# Altium schematic sheet 电源.SchDoc
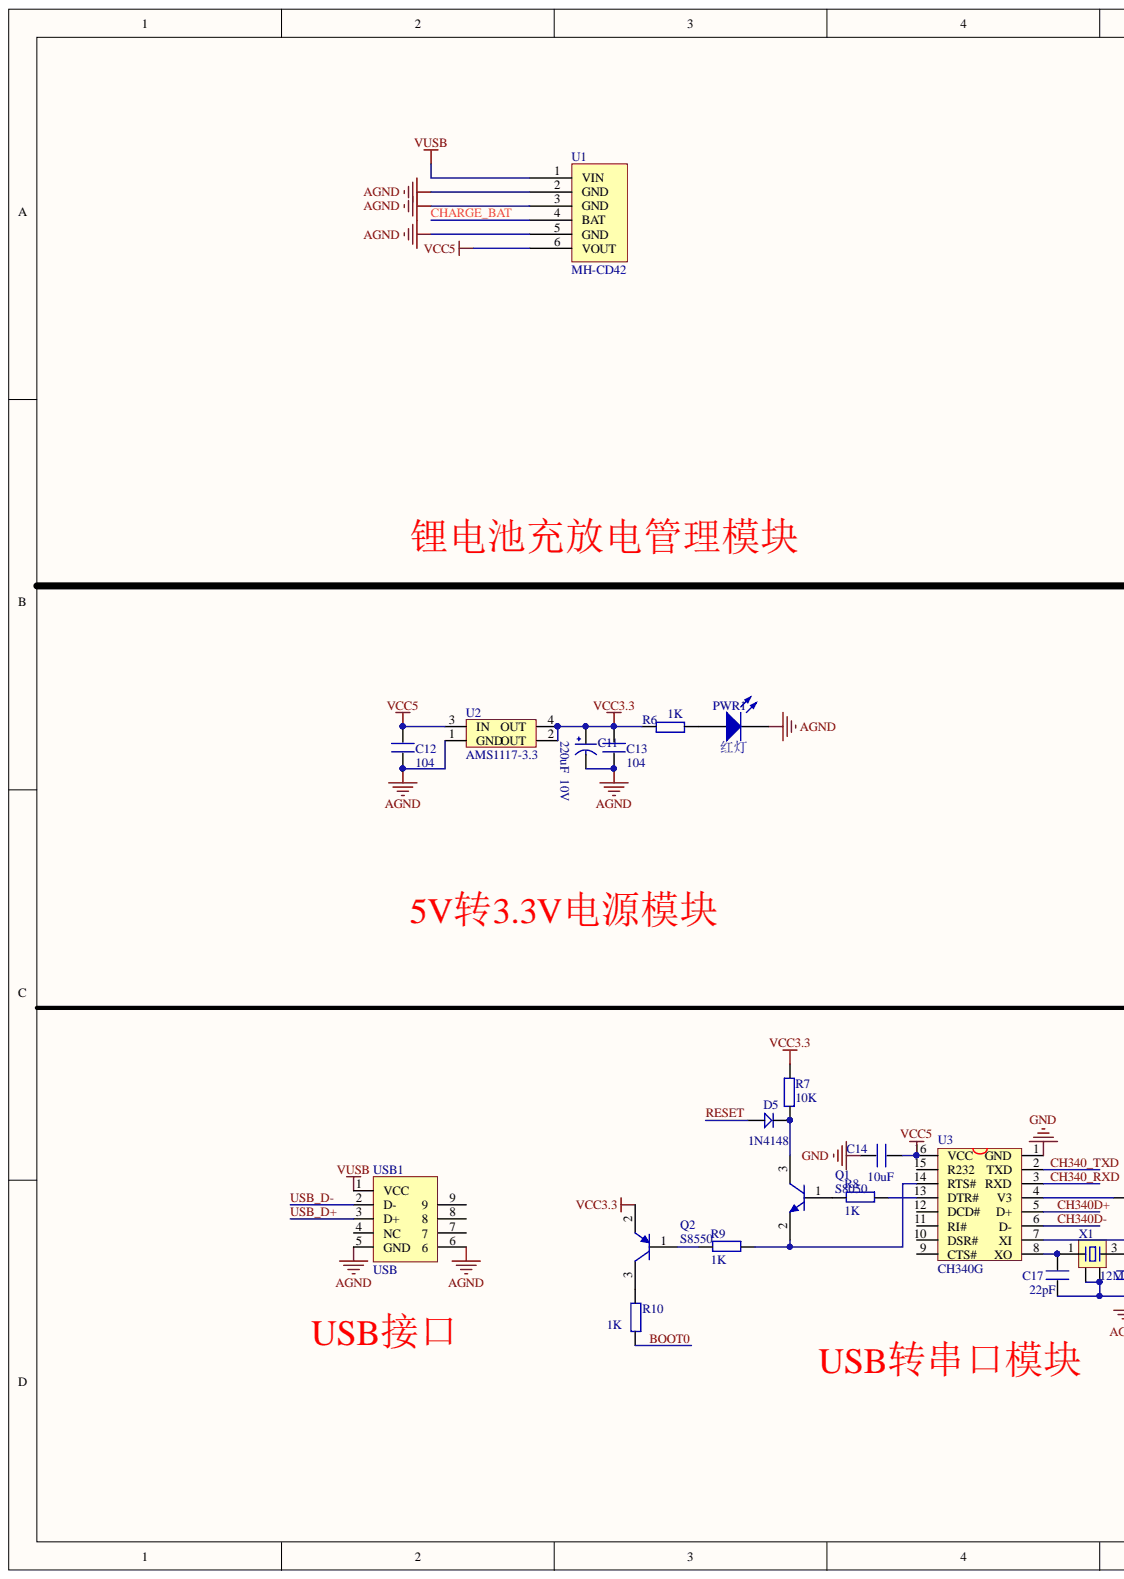
[diagram p0: 电源.SchDoc, left]
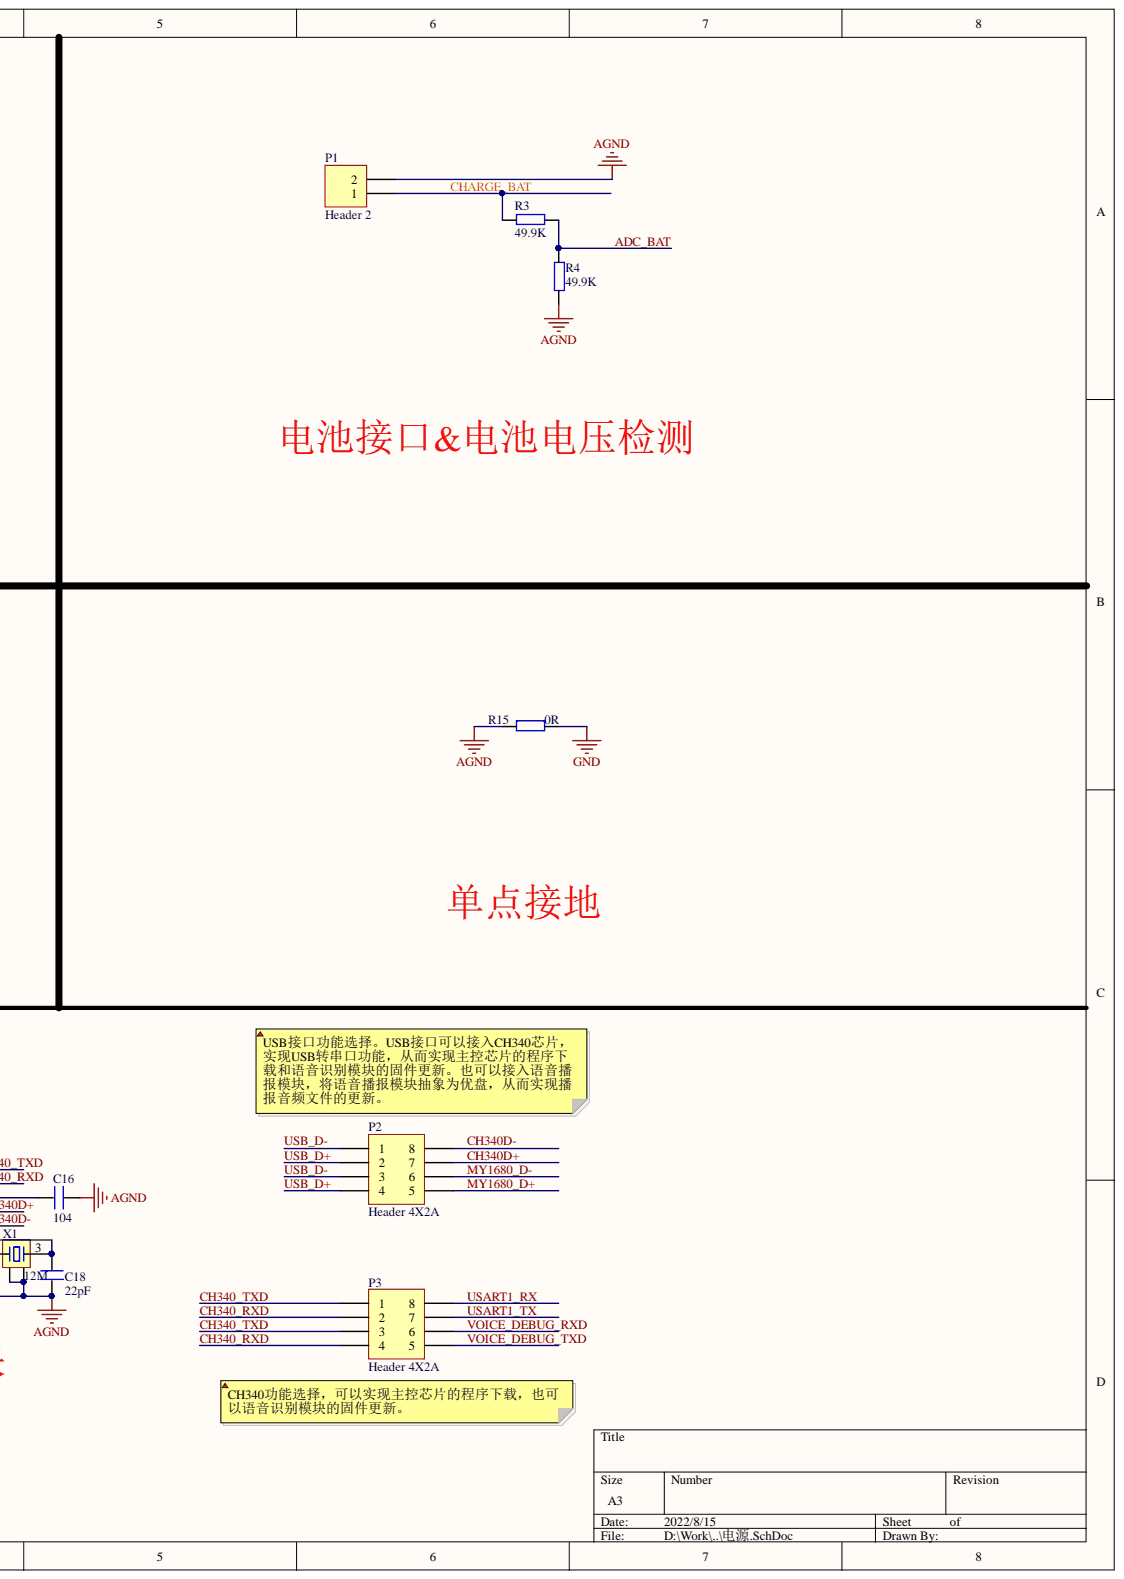
[diagram p1: 电源.SchDoc, right]

## Parts
C11  220uF  10V  [CC6.56.5]
C12  104  [0603C]
C13  104  [0603C]
C14  10uF  [0603C]
C16  104  [0603C]
C17  22pF  [0603C]
C18  22pF  [0603C]
D5  1N4148  [DIODE_SOP]
P1  Header 2  [XH2.54]
P2  Header 4X2A  [拨码开关]
P3  Header 4X2A  [拨码开关]
PWR1  红灯  [LC-0805_LED]
Q1  S8050  [SOT-23]
Q2  S8550  [SOT-23]
R3  49.9K  [0603R]
R4  49.9K  [0603R]
R6  1K  [0603R]
R7  10K  [0603R]
R8  1K  [0603R]
R9  1K  [0603R]
R10  1K  [0603R]
R15  value 0R  [0603R]  (hidden comment: RES)
U1  MH-CD42  [MH-CD42]
U2  AMS1117-3.3  [AMS1117]
U3  CH340G  [SOP-16]
USB1  USB  [MINIUSB]
X1  12M  [3225]

## Nets
AGND: C11.2 C12.1 C13.1 C16.1 C17.2 C18.2 P1.2 PWR1.2 R4.1 R15.2 U1.2(GND) U1.3(GND) U1.5(GND) U2.1(GND) USB1.5(GND) USB1.6 X1.2 X1.4
GND: C14.2 R15.1 U3.1(GND)
VCC3.3: C11.1 C13.2 Q2.2 R6.1 R7.1 U2.2(OUT) U2.4(OUT)
VCC5: C12.2 C14.1 U1.6(VOUT) U2.3(IN) U3.16(VCC)
VUSB: U1.1(VIN) USB1.1(VCC)
ADC_BAT: R3.1 R4.2
BOOT0: R10.1
CH340_RXD: P3.2 P3.4 U3.3(RXD)
CH340_TXD: P3.1 P3.3 U3.2(TXD)
CH340D+: P2.7 U3.5(D+)
CH340D-: P2.8 U3.6(D-)
CHARGE_BAT: P1.1 R3.2 U1.4(BAT)
MY1680_D+: P2.5
MY1680_D-: P2.6
RESET: D5.1
USART1_RX: P3.8
USART1_TX: P3.7
USB_D+: P2.2 P2.4 USB1.3(D+)
USB_D-: P2.1 P2.3 USB1.2(D-)
VOICE_DEBUG_RXD: P3.6
VOICE_DEBUG_TXD: P3.5
NetC16_2: C16.2 U3.4(V3)
NetC17_1: C17.1 U3.8(XO) X1.1
NetC18_1: C18.1 U3.7(XI) X1.3
NetD5_2: D5.2 Q1.3 R7.2
NetPWR1_1: PWR1.1 R6.2
NetQ1_1: Q1.1 R8.2
NetQ1_2: Q1.2 R9.1 U3.14(RTS#)
NetQ2_1: Q2.1 R9.2
NetQ2_3: Q2.3 R10.2
NetR8_1: R8.1 U3.13(DTR#)

Not connected: U3 9(CTS#) 10(DSR#) 11(RI#) 12(DCD#) 15(R232); USB1 4(NC) 7 8 9

## Library data of the parts
C11 = CAP: Component Kind: Standard; Library Name: WarShip V3 STM32103封装库.IntLib
C12-C14 C16-C18 = C: Component Kind: Standard; Library Name: WarShip V3 STM32103封装库.IntLib
D5 = D: Component Kind: Standard; Library Name: WarShip V3 STM32103封装库.IntLib
P1 = Header 2: Header, 2-Pin; Component Kind: Standard; LatestRevisionDate: 17-Jul-2002; LatestRevisionNote: Re-released for DXP Platform.; Library Name: Miscellaneous Connectors.IntLib; Publisher: Altium Limited
P2 P3 = Header 4X2A: Header, 4-Pin, Dual row; Component Kind: Standard; LatestRevisionDate: 17-Jul-2002; LatestRevisionNote: Re-released for DXP Platform.; Library Name: Miscellaneous Connectors.IntLib; Signal Integrity: Connector; Publisher: Altium Limited
PWR1 = LED2: Typical RED, GREEN, YELLOW, AMBER GaAs LED; Component Kind: Standard; LatestRevisionDate: 17-Jul-2002; LatestRevisionNote: Re-released for DXP Platform.; Library Name: WarShip V3 STM32103封装库.IntLib; PackageDocument: Feb-2002; PackageReference: 3.2X1.6X1.1; Published: 8-Jun-2000; Simulation: LED2; Publisher: Altium Limited
Q1 = NPN: Component Kind: Standard; Description: NPN; Library Name: Basic.SchLib
Q2 = 2N3906: PNP General Purpose Amplifier; Component Kind: Standard; ComponentLink1Description: Manufacturer Link; ComponentLink1URL: http://www.fairchildsemi.com/; ComponentLink2Description: Datasheet; ComponentLink2URL: http://www.fairchildsemi.com/ds/2N%2F2N3906.pdf; DatasheetVersion: 1997; LatestRevisionDate: 15-Jan-2003; LatestRevisionNote: PCB Footprint 'BCY-W3/D4.7' replaced; Library Name: Miscellaneous Devices.IntLib; PackageDescription: TO, Flat Index; 3 In-Line, Axial Leads; Body Dia. 4.5mm; Leads 0.51 x 0.48 mm (max); PackageReference: TO-92A; PackageVersion: Sep-1998; Published: 8-Jun-2000; Signal Integrity: 2N3906; Simulation: 2N3906; Publisher: Altium Limited
R3 R4 R6-R10 = R: Component Kind: Standard; Library Name: WarShip V3 STM32103封装库.IntLib
U1 = MH-CD42: 锂电池充放电管理芯片; Component Kind: Standard; Library Name: WIFI气象站.SchLib
U2 = AMS1117: Component Kind: Standard; Library Name: WarShip V3 STM32103封装库.IntLib
U3 = CH340G: USB2UART; Component Kind: Standard; Library Name: WarShip V3 STM32103封装库.IntLib
USB1 = USB5: Component Kind: Standard; Library Name: ZigBee实验箱.SCHLIB
X1 = XTAL-4P: 4脚无源晶振; 版权归属: 源创客; BOM名称: 4脚无源晶振; Component Kind: Standard; Library Name: 10.1 - 保险丝-晶振.SchLib; 学习资源: www.ooocke.com
R15 = RES: Component Kind: Standard; Description: RES; Library Name: 主控端最小系统.SCHLIB
